# Altium schematic sheet mmb-2016-003_power.schdoc(mmb-2016-003_power)
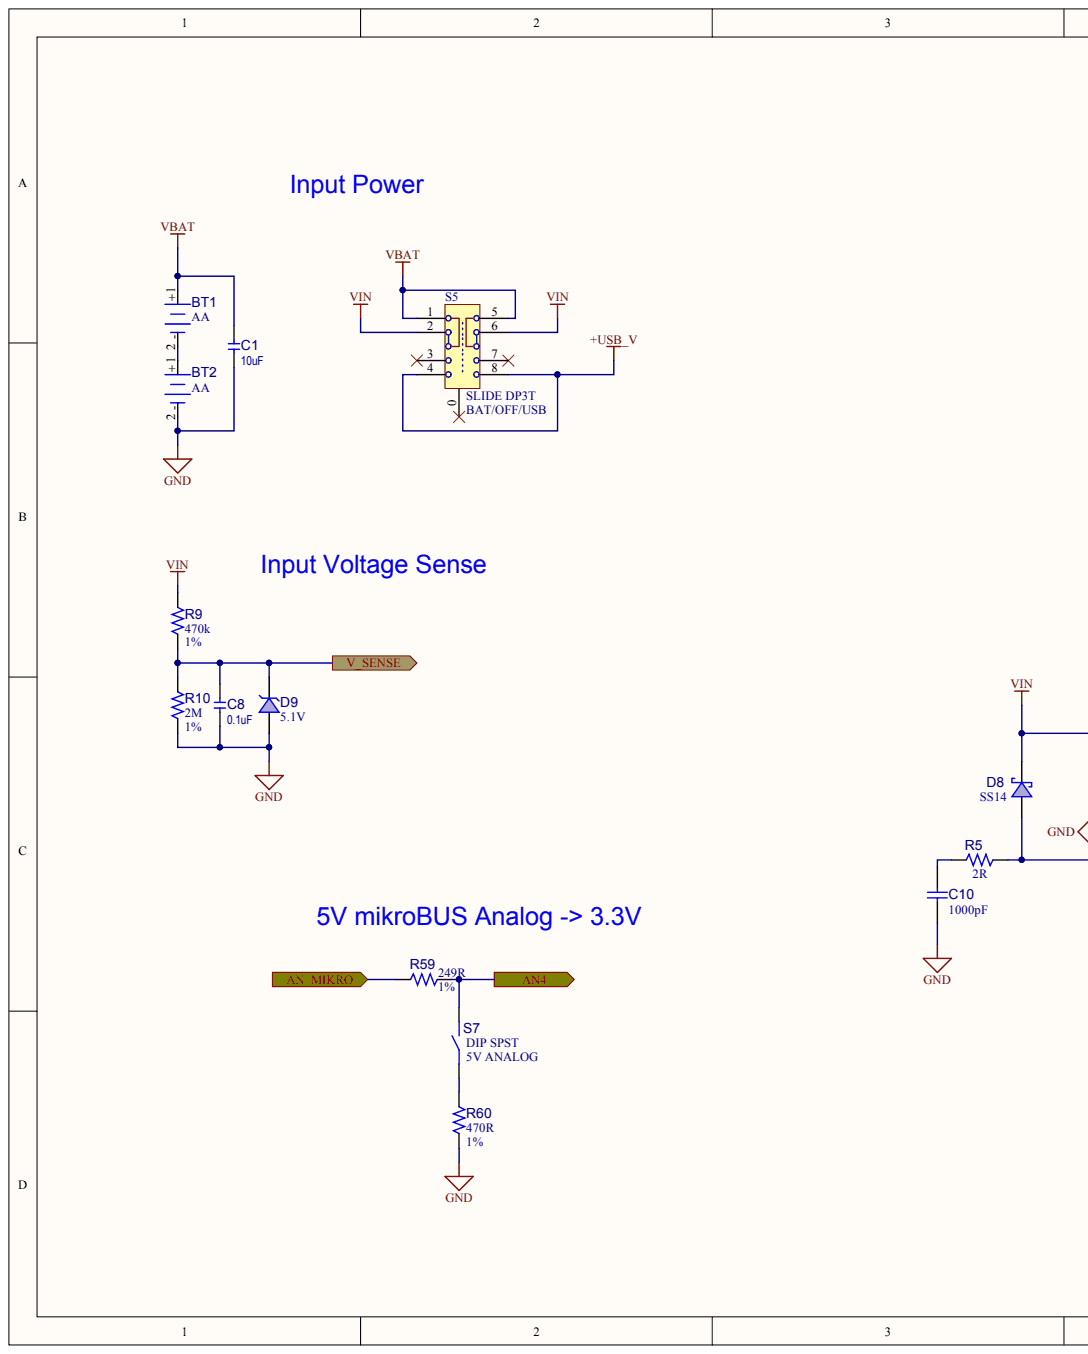
[diagram p0: mmb-2016-003_power.schdoc, left]
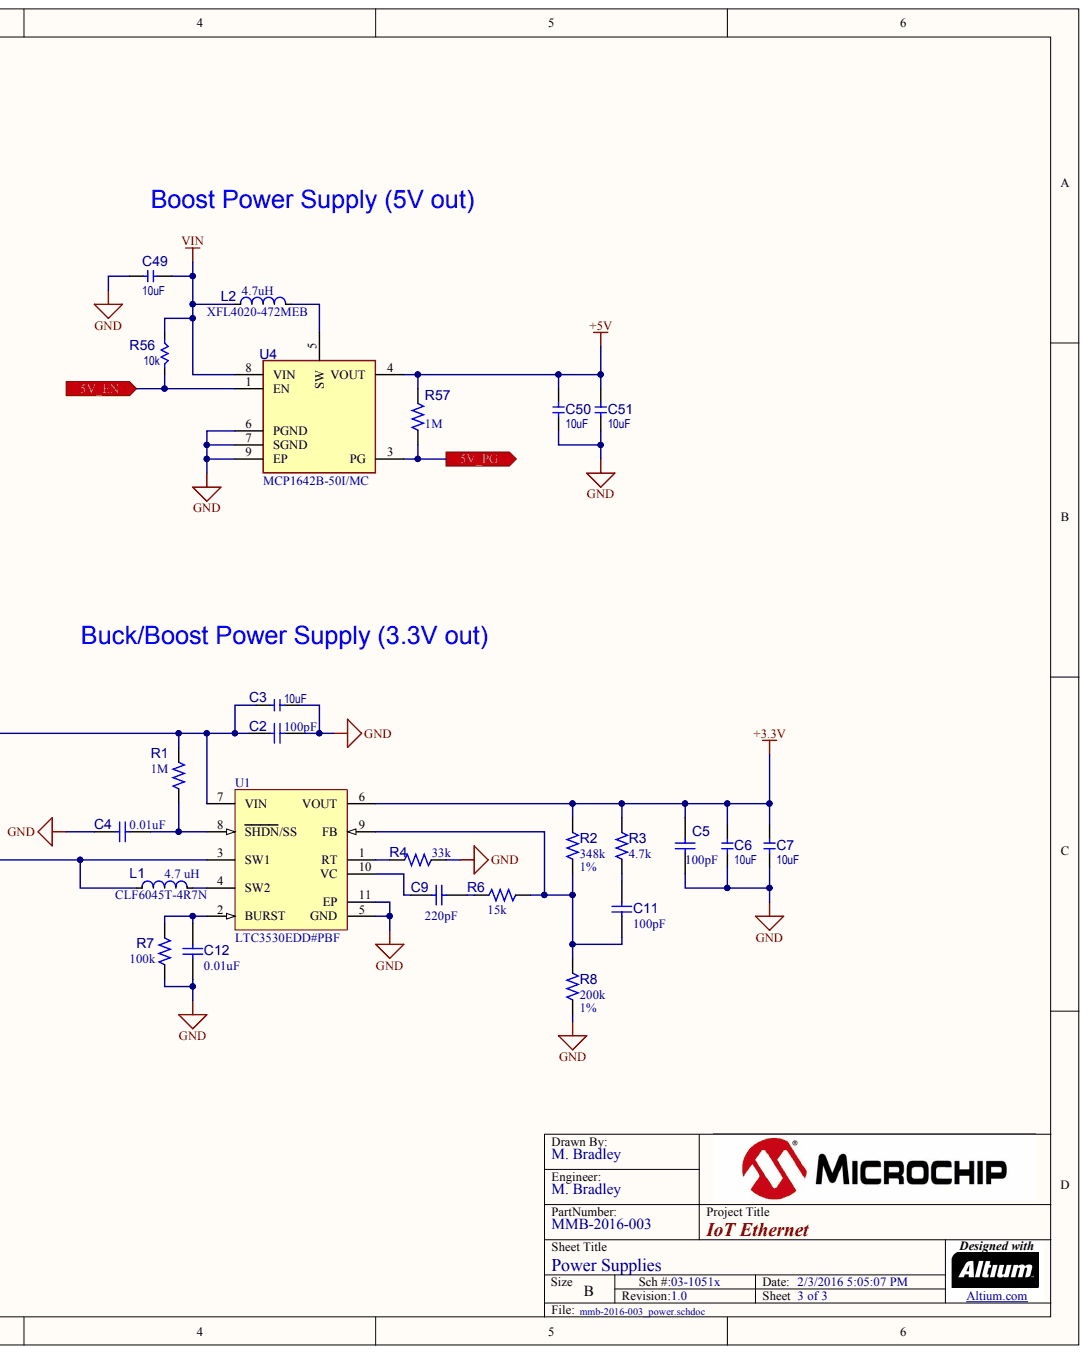
[diagram p1: mmb-2016-003_power.schdoc, right]

## Parts
BT1  value AA  [BAT_HOLDER_AA_2460]  (hidden comment: Battery 2 Pin)
BT2  value AA  [BAT_HOLDER_AA_2460]  (hidden comment: Battery 2 Pin)
C1  value 10uF  [CAP_C_0805]  (hidden comment: Capacitor NP)
C2  value 100pF  [CAP_C_0603]  (hidden comment: Capacitor NP)
C3  value 10uF  [CAP_C_0805]  (hidden comment: Capacitor NP)
C4  value 0.01uF  [CAP_C_0603]  (hidden comment: Capacitor NP)
C5  value 100pF  [CAP_C_0603]  (hidden comment: Capacitor NP)
C6  value 10uF  [CAP_C_0805]  (hidden comment: Capacitor NP)
C7  value 10uF  [CAP_C_0805]  (hidden comment: Capacitor NP)
C8  value 0.1uF  [CAP_C_0603]  (hidden comment: Capacitor NP)
C9  value 220pF  [CAP_C_0603]  (hidden comment: Capacitor NP)
C10  value 1000pF  [CAP_C_0805]  (hidden comment: Capacitor NP)
C11  value 100pF  [CAP_C_0603]  (hidden comment: Capacitor NP)
C12  value 0.01uF  [CAP_C_0603]  (hidden comment: Capacitor NP)
C49  value 10uF  [CAP_C_0805]  (hidden comment: Capacitor NP)
C50  value 10uF  [CAP_C_0805]  (hidden comment: Capacitor NP)
C51  value 10uF  [CAP_C_0805]  (hidden comment: Capacitor NP)
D8  value SS14  [DO-214AC_SMA]  (hidden comment: Diode Schottky)
D9  value 5.1V  [SOT-23-3]  (hidden comment: Diode Zener)
L1  CLF6045T-4R7N  value 4.7 uH  [IND_TDK_CLF6045]
L2  value 4.7uH  Manufacturer Part Number 1: XFL4020-472MEB  [IND_CFT_XFL4020_L4W4H2.1]  (hidden comment: Inductor)
R1  value 1M  [RES_C_0603]  (hidden comment: Resistor)
R2  value 348k  Tolerance: 1%  [RES_C_0603]  (hidden comment: Resistor)
R3  value 4.7k  [RES_C_0603]  (hidden comment: Resistor)
R4  value 33k  [RES_C_0603]  (hidden comment: Resistor)
R5  value 2R  [RES_C_0805]  (hidden comment: Resistor)
R6  value 15k  [RES_C_0603]  (hidden comment: Resistor)
R7  value 100k  [RES_C_0603]  (hidden comment: Resistor)
R8  value 200k  Tolerance: 1%  [RES_C_0603]  (hidden comment: Resistor)
R9  value 470k  Tolerance: 1%  [RES_C_0603]  (hidden comment: Resistor)
R10  value 2M  Tolerance: 1%  [RES_C_0603]  (hidden comment: Resistor)
R56  value 10k  [RES_C_0603]  (hidden comment: Resistor)
R57  value 1M  [RES_C_0603]  (hidden comment: Resistor)
R59  value 249R  Tolerance: 1%  [RES_C_0603]  (hidden comment: Resistor)
R60  value 470R  Tolerance: 1%  [RES_C_0603]  (hidden comment: Resistor)
S5  BAT/OFF/USB  value SLIDE DP3T  [SW_SLIDE_TH_OS203012MU5QP1]
S7  5V ANALOG  value DIP SPST  Type: DIP  [SW_SMD_2.54MM X 1 Wurth]
U1  LTC3530EDD#PBF  [LT-DD-10_V]
U4  MCP1642B-50I/MC  [DFN-8_2x3_VIAS]

## Ports
5V_EN, 5V_PG, AN4, AN_MIKRO, V_SENSE

## Nets
+3.3V: C5.2 C6.2 C7.2 R2.2 R3.2 U1.6(VOUT)
+5V: C50.1 C51.1 R57.2 U4.4(VOUT)
+USB_V: S5.4 S5.8
GND: BT2.2 C1.1 C2.1 C3.1 C4.2 C5.1 C6.1 C7.1 C8.1 C10.1 C12.1 C49.1 C50.2 C51.2 D9.1 R4.1 R7.1 R8.1 R10.1 R60.1 U1.5(GND) U1.11(EP) U4.6(PGND) U4.7(SGND) U4.9(EP)
VBAT: BT1.1 C1.2 S5.1 S5.5
VIN: C2.2 C3.2 C49.2 D8.2 L2.1 R1.2 R9.2 R56.2 S5.2 S5.6 U1.7(VIN) U4.8(VIN)
5V_EN: R56.1 U4.1(EN) ; port 5V_EN
5V_PG: R57.1 U4.3(PG) ; port 5V_PG
AN4: R59.2 S7.2 ; port AN4
AN_MIKRO: R59.1 ; port AN_MIKRO
V_SENSE: C8.2 D9.3 R9.1 R10.2 ; port V_SENSE
NetBT1_2: BT1.2 BT2.1
NetC4_1: C4.1 R1.1 U1.8(SHDN/SS)
NetC9_1: C9.1 U1.10(VC)
NetC9_2: C9.2 R6.1
NetC10_2: C10.2 R5.1
NetC11_1: C11.1 R2.1 R6.2 R8.2 U1.9(FB)
NetC11_2: C11.2 R3.1
NetC12_2: C12.2 R7.2 U1.2(BURST)
NetD8_1: D8.1 L1.1 R5.2 U1.3(SW1)
NetL1_2: L1.2 U1.4(SW2)
NetL2_2: L2.2 U4.5(SW)
NetR4_2: R4.2 U1.1(RT)
NetR60_2: R60.2 S7.1

Not connected: S5 0 3 7

## Library data of the parts
BT1 BT2 = BAT1011: Battery; Manufacturer 1: Keystone Electronics; Manufacturer Part Number 1: 2460; Description_: BATT HOLDER 2 TH AA; Owner: Tad Ahlvers; Populated: YES; Category: Battery; Component Kind: Standard; ComponentLink1Description: Datasheet; ComponentLink1URL: https://chn-vm-apps.microchip.com/mchprepo/parts/Datasheets/2460.pdf; Database Table Name: Battery; Library Name: MCHP-CDB-Offline.DbLib; MCHP-ID: BAT1011; Quantity Override: 1; Revision: A; Status: Design; Supplier 1: Digi-Key; Supplier Part Number 1: 2460K-ND; Type: Holder
C1 C3 C6 C7 C49-C51 = CAP0373: Capacitor; Manufacturer 1: Murata; Manufacturer Part Number 1: GRM21BR61C106KE15L; Description_: CAP CER 10uF 16V 10% X5R SMD 0805; Populated: Yes; Temperature Coeffcient: X5R; Tolerance: 10%; Type: Ceramic; Voltage: 16V; Category: Capacitor; Component Kind: Standard; ComponentLink1Description: Datasheet; ComponentLink1URL: https://chn-vm-apps.microchip.com/mchprepo/parts/Datasheets/L0005E.pdf; Database Table Name: Capacitor; Library Name: MCHP-CDB.DbLib; Manufacturer 2: Taiyo Yuden; Manufacturer Part Number 2: EMK212BJ106KG-T; MCHP-ID: CAP0373; Operating Temperature: +25C to +125C; Package: 0805; Quantity Override: 1; Revision: 1; Status: Production; Supplier 1: Digi-Key; Supplier 2: Digi-Key; Supplier 3: Newark; Supplier Part Number 1: 490-3886-1-ND; Supplier Part Number 2: 587-1295-1-ND; Supplier Part Number 3: 30K5459
C2 C5 C11 = CAP0035: Capacitor; Manufacturer 1: Panasonic; Manufacturer Part Number 1: ECU-V1H101JCV; Description_: CAP CER 100pF 50V 5% NP0 SMD 0603; Populated: Yes; Temperature Coeffcient: NP0; Tolerance: 5%; Type: Ceramic; Voltage: 50V; Category: Capacitor; Component Kind: Standard; ComponentLink1Description: Datasheet; ComponentLink1URL: https://chn-vm-apps.microchip.com/mchprepo/parts/Datasheets/ECJ__General_.pdf; Database Table Name: Capacitor; Library Name: MCHP-CDB-Offline.DbLib; Manufacturer 2: Cal-Chip; Manufacturer 3: NIC Components; Manufacturer 4: Panasonic; Manufacturer 5: AVX; Manufacturer 6: TDK; Manufacturer Part Number 2: GMC10CG101J50NTLF; Manufacturer Part Number 3: NMC0603NPO101J50TRPF; Manufacturer Part Number 4: ECJ-1VC1H101J; Manufacturer Part Number 5: 06035A101JAT2A; Manufacturer Part Number 6: C1608C0G1H101J/10; MCHP-ID: CAP0035; Operating Temperature: -55C to +125C; Package: 0603; Quantity Override: 1; Revision: 2; Status: Production; Supplier 1: Digi-Key; Supplier 2: Cal-Chip; Supplier 3: NIC Components; Supplier 4: Digi-Key; Supplier 5: Digi-Key; Supplier 6: Digi-Key; Supplier Part Number 1: PCC101ACVTR-ND; Supplier Part Number 2: GMC10CG101J50NTLF; Supplier Part Number 3: NMC0603NPO101J50TRPF; Supplier Part Number 4: PCC101ACVCT-ND; Supplier Part Number 5: 478-1175-1-ND; Supplier Part Number 6: C1608C0G1H101J/10-ND
C4 C12 = CAP0451: Capacitor; Manufacturer 1: Samsung; Manufacturer Part Number 1: CL10B103KO8NNNC; Description_: CAP CER 0.01uF 16V 10% X7R SMD 0603; Populated: Yes; Temperature Coeffcient: X7R; Tolerance: 10%; Type: Ceramic; Voltage: 16V; Category: Capacitor; Component Kind: Standard; ComponentLink1Description: Datasheet; ComponentLink1URL: https://chn-vm-apps.microchip.com/mchprepo/parts/Datasheets/L0005E.pdf; Database Table Name: Capacitor; Library Name: MCHP-CDB-Offline.DbLib; Manufacturer 2: Murata; Manufacturer 3: Panasonic; Manufacturer 4: Johanson Dielectrics; Manufacturer Part Number 2: GRM188R71C103KA01D; Manufacturer Part Number 3: ECJ-1VB1C103K; Manufacturer Part Number 4: 160R14W103KV4T; MCHP-ID: CAP0451; Operating Temperature: -55C to +125C; Package: 0603; Quantity Override: 1; Revision: 1; Status: Production; Supplier 1: Digi-Key; Supplier 2: Digi-Key; Supplier 3: Digi-Key; Supplier 4: Digi-Key; Supplier Part Number 1: 1276-1926-1-ND; Supplier Part Number 2: 490-1525-1-ND; Supplier Part Number 3: PCC1750CT-ND; Supplier Part Number 4: 709-1152-2-ND
C8 = CAP1166: Capacitor; Manufacturer 1: TDK; Manufacturer Part Number 1: C1608X7R1C104K; Description_: CAP CER 0.1uF 16V 10% X7R 0603; Owner: Bogdan P (M91398); Populated: Yes; Temperature Coeffcient: X7R; Tolerance: 10%; Type: Ceramic; Voltage: 16V; Category: Capacitor; Component Kind: Standard; ComponentLink1Description: Datasheet; ComponentLink1URL: https://chn-vm-apps.microchip.com/mchprepo/parts/Datasheets/general_B11.pdf; Database Table Name: Capacitor; Library Name: MCHP-CDB.DbLib; MCHP-ID: CAP1166; Operating Temperature: -55C to +125C; Package: 0603; Quantity Override: 1; Revision: 0; Status: Production; Supplier 1: Digi-Key; Supplier Part Number 1: 445-1317-1-ND
C9 = CAP0552: Capacitor; Manufacturer 1: Panasonic; Manufacturer Part Number 1: ECJ-1VC1H221J; Description_: CAP CER 220pF 50V 5% NP0 SMD 0603; Populated: Yes; Temperature Coeffcient: NP0; Tolerance: 5%; Type: Ceramic; Voltage: 50V; Category: Capacitor; Component Kind: Standard; ComponentLink1Description: Datasheet; ComponentLink1URL: https://chn-vm-apps.microchip.com/mchprepo/parts/Datasheets/KEM_C1002_X7R_SMD.pdf; Database Table Name: Capacitor; Library Name: MCHP-CDB-Offline.DbLib; Manufacturer 2: ROHM; Manufacturer 3: KEMET; Manufacturer 4: TDK; Manufacturer Part Number 2: MCH185A221JK; Manufacturer Part Number 3: C0603C221J5GACTU; Manufacturer Part Number 4: C1608C0G1H221J; MCHP-ID: CAP0552; Operating Temperature: -55C to +125C; Package: 0603; Quantity Override: 1; Revision: 2; Status: Production; Supplier 1: Digi-Key; Supplier 2: Digi-Key; Supplier 3: Digi-Key; Supplier 4: Digi-Key; Supplier Part Number 1: PCC221ACVCT-ND; Supplier Part Number 2: 511-1146-1-ND; Supplier Part Number 3: 399-1066-1-ND; Supplier Part Number 4: 445-1285-1-ND
C10 = CAP0505: Capacitor; Manufacturer 1: Yageo; Manufacturer Part Number 1: CC0805KRX7R9BB102; Description_: CAP CER 1000pF 50V 10% X7R SMD 0805; Populated: Yes; Temperature Coeffcient: X7R; Tolerance: 10%; Type: Ceramic; Voltage: 50V; Category: Capacitor; Component Kind: Standard; ComponentLink1Description: Datasheet; ComponentLink1URL: https://chn-vm-apps.microchip.com/mchprepo/parts/Datasheets/MLCC_ordering_code.pdf; Database Table Name: Capacitor; Library Name: MCHP-CDB-Offline.DbLib; MCHP-ID: CAP0505; Operating Temperature: -55C to +125C; Package: 0805; Quantity Override: 1; Revision: 1; Status: Production; Supplier 1: Digi-Key; Supplier Part Number 1: 311-1127-1-ND
D8 = DIODE0150: Manufacturer 1: Diodes Incorporated; Manufacturer Part Number 1: B140-13; Description_: DIO SCTKY SS14 500mV 1A 40V DO-214AC_SMA; Forward Current: 1A; Populated: Yes; Reverse Current: 200uA; Reverse Voltage: 40V; Type: Schottky Single; Category: Diode; Component Kind: Standard; ComponentLink1Description: Datasheet; ComponentLink1URL: https://chn-vm-apps.microchip.com/mchprepo/parts/Datasheets/ss12.pdf; Database Table Name: Diode; Library Name: MCHP-CDB-Offline.DbLib; Manufacturer 2: Diodes Incorporated; Manufacturer 3: Vishay; Manufacturer Part Number 2: B140-13-F; Manufacturer Part Number 3: SS14-E3/61T; MCHP-ID: DIODE0150; Operating Temperature: -65C to +125C; Package: DO-214AC_SMA; Quantity Override: 1; Revision: 2; Status: Production; Supplier 1: Digi-Key; Supplier 2: Diodes Incorporated; Supplier 3: Digi-Key; Supplier Part Number 1: B140DICT-ND; Supplier Part Number 2: B140-13-F; Supplier Part Number 3: SS14-E3/61TGICT-ND
D9 = DIODE0142: Manufacturer 1: Fairchild; Manufacturer Part Number 1: BZX84C5V1; Description_: DIO ZNR BZX84C5V1 5.1V 350mW SOT-23-3; Forward Current: 250mA; Populated: Yes; Power: 350mW; Type: Zener Single; Category: Diode; Component Kind: Standard; ComponentLink1Description: Datasheet; ComponentLink1URL: https://chn-vm-apps.microchip.com/mchprepo/parts/Datasheets/BZX84C10.pdf; Database Table Name: Diode; Library Name: MCHP-CDB-Offline.DbLib; MCHP-ID: DIODE0142; Operating Temperature: -55C to +150C; Package: SOT-23-3; Quantity Override: 1; Revision: 1; Status: Production; Supplier 1: Digi-Key; Supplier Part Number 1: BZX84C5V1FSCT-ND
L1 = IND_TDK_CLF6045: IND TDK CLF6045; Desciption_: Inductor TDK CLF6045T-4R7N 4.7uH; MCHP-ID: IND1182; Populated: Yes; Component Kind: Standard; Manufacturer 1: TDK; Manufacturer Part Number 1: CLF6045T-4R7N; Quantity Override: 1; Supplier 1: Digi-Key; Supplier Part Number 1: 445-15090-1-ND; Library Name: MMB-2014-002a.SchLib
L2 = IND1008: Manufacturer 1: Coilcraft; DCR: 0.0574R; Description_: INDUCTOR 4.7uH 2A 20% SMD XFL4020; Owner: Brent Lanphear; Populated: Yes; RMS Current: 5A; Saturation Current: 2A; Test Frequency: 100kHz; Category: Inductor; Component Kind: Standard; ComponentLink1Description: Datasheet; ComponentLink1URL: https://chn-vm-apps.microchip.com/mchprepo/parts/Datasheets/XFL4020.pdf; Database Table Name: Inductor; Description: Inductor; Library Name: MCHP-CDB-Offline.DbLib; MCHP-ID: IND1008; Operating Temperature: -40C to +125C; Package: SMD_XFL4020; Quantity Override: 1; Revision: 2; Status: Production; Supplier 1: Coilcraft; Supplier Part Number 1: XFL4020-472MEB; Type: Inductor
R1 R57 = RSMT0187: Manufacturer 1: Yageo; Manufacturer Part Number 1: 9C06031A1004JLHFT; Description_: RES TKF 1M 5% 1/10W SMD 0603; Populated: Yes; Power: 1/10W; Temperature Coeffcient: 100ppm/C; Tolerance: 5%; Voltage: 50V; Category: Resistor; Component Kind: Standard; ComponentLink1Description: Datasheet; ComponentLink1URL: https://chn-vm-apps.microchip.com/mchprepo/parts/Datasheets/PYu-RC0603_51_RoHS_L_4.pdf; Composition: TKF; Database Table Name: Resistor; Description: Resistor; Library Name: MCHP-CDB-Offline.DbLib; Manufacturer 2: KOA Speer; Manufacturer 3: Panasonic; Manufacturer 4: Stackpole Electronics Inc; Manufacturer 5: Yageo; Manufacturer 6: Yageo; Manufacturer 7: Stackpole Electronics Inc; Manufacturer Part Number 2: RK73B1JTTD105J; Manufacturer Part Number 3: ERJ-3GEYJ105V; Manufacturer Part Number 4: RMCF1/161M5%R; Manufacturer Part Number 5: RC0603JR-071ML; Manufacturer Part Number 6: 9C06031A1004JLPFT; Manufacturer Part Number 7: RMCF0603JT1M00; MCHP-ID: RSMT0187; Operating Temperature: -55C to +155C; Package: 0603; Quantity Override: 1; Revision: 1; Status: Production; Supplier 1: Yageo; Supplier 2: KOA Speer; Supplier 3: Digi-Key; Supplier 4: Stackpole Electronics Inc; Supplier 5: Yageo; Supplier 6: Yageo; Supplier 7: Digi-Key; Supplier Part Number 1: 9C06031A1004JLHFT; Supplier Part Number 2: RK73B1JTTD105J; Supplier Part Number 3: P1.0MGTR-ND; Supplier Part Number 4: RMCF1/161M5%R; Supplier Part Number 5: RC0603JR-071ML; Supplier Part Number 6: 9C06031A1004JLPFT; Supplier Part Number 7: RMCF0603JT1M00CT-ND; Type: RES SMD
R2 = RSMT1057: Manufacturer 1: Stackpole Electronics Inc; Manufacturer Part Number 1: RMCF0603FT348K; Current: 1A; Description_: RES TKF 348k 1/10W 1% SMD 0603; Owner: Leah Whitaker; Populated: YES; Power: 1/10W; Temperature Coeffcient: 100ppm/C; Voltage: 50V; Category: Resistor; Component Kind: Standard; ComponentLink1Description: Datasheet; ComponentLink1URL: https://chn-vm-apps.microchip.com/mchprepo/parts/Datasheets/SEI-RMCF_RMCP.pdf; Composition: TKF; Database Table Name: Resistor; Description: Resistor; Library Name: MCHP-CDB-Offline.DbLib; MCHP-ID: RSMT1057; Operating Temperature: -55C to +155C; Package: 0603; Quantity Override: 1; Revision: 0; Status: Production; Supplier 1: Digi-Key; Supplier Part Number 1: RMCF0603FT348KCT-ND; Type: RES SMD
R3 = RSMT0092: Manufacturer 1: Yageo; Manufacturer Part Number 1: RC0603JR-074K7L; Description_: RES TKF 4.7k 5% 1/10W SMD 0603; Populated: Yes; Power: 1/10W; Temperature Coeffcient: 100ppm/C; Tolerance: 5%; Voltage: 50V; Category: Resistor; Component Kind: Standard; ComponentLink1Description: Datasheet; ComponentLink1URL: https://chn-vm-apps.microchip.com/mchprepo/parts/Datasheets/PYu-RC0603_51_RoHS_L_4.pdf; Composition: TKF; Database Table Name: Resistor; Description: Resistor; Library Name: MCHP-CDB-Offline.DbLib; Manufacturer 2: Yageo; Manufacturer 3: KOA Speer; Manufacturer 4: Panasonic; Manufacturer 5: ROHM; Manufacturer 6: Stackpole Electronics Inc; Manufacturer 7: Yageo; Manufacturer 8: NIC Components; Manufacturer Part Number 2: 9C06031A4701JLHFT; Manufacturer Part Number 3: RK73B1JTTD472J; Manufacturer Part Number 4: ERJ-3GEYJ472V; Manufacturer Part Number 5: MCR03EZHJ4.7K; Manufacturer Part Number 6: RMCF 1/16 4.7K 5% R; Manufacturer Part Number 7: 9C06031A4701JLPFT; Manufacturer Part Number 8: NRC06J472TRF; MCHP-ID: RSMT0092; Operating Temperature: -55C to +155C; Package: 0603; Quantity Override: 1; Revision: 1; Status: Production; Supplier 1: Digi-Key; Supplier 2: Digi-Key; Supplier 3: KOA Speer; Supplier 4: Digi-Key; Supplier 5: Digi-Key; Supplier 6: ROHM; Supplier 7: Stackpole Electronics Inc; Supplier 8: Yageo; Supplier 9: Avnet; Supplier Part Number 1: 311-4.7KGRTR-ND; Supplier Part Number 2: 311-4.7KGTR-ND; Supplier Part Number 3: RK73B1JTTD472J; Supplier Part Number 4: P4.7KGTR-ND; Supplier Part Number 5: P4.7KGCT-ND; Supplier Part Number 6: MCR03EZHJ4.7K; Supplier Part Number 7: RMCF 1/16 4.7K 5% R; Supplier Part Number 8: 9C06031A4701JLPFT; Supplier Part Number 9: NRC06J472TRF; Type: RES SMD
R4 = RSMT0499: Manufacturer 1: Panasonic; Manufacturer Part Number 1: ERJ-3GEYJ333V; Description_: RES TKF 33k 5% 1/10W SMD 0603; Populated: Yes; Power: 1/10W; Temperature Coeffcient: 200ppm/C; Tolerance: 5%; Voltage: 75V; Category: Resistor; Component Kind: Standard; ComponentLink1Description: Datasheet; ComponentLink1URL: https://chn-vm-apps.microchip.com/mchprepo/parts/Datasheets/AOA0000CE1.pdf; Composition: TKF; Database Table Name: Resistor; Description: Resistor; Library Name: MCHP-CDB-Offline.DbLib; MCHP-ID: RSMT0499; Operating Temperature: -55C to +155C; Package: 0603; Quantity Override: 1; Revision: 1; Status: Production; Supplier 1: Digi-Key; Supplier 2: Digi-Key; Supplier Part Number 1: P33KGTR-ND; Supplier Part Number 2: P33KGCT-ND; Type: RES SMD
R5 = RSMT0359: Manufacturer 1: Yageo; Manufacturer Part Number 1: 9C08052A2R00FGHFT; Description_: RES TKF 2R 1% 1/8W SMD 0805; Populated: Yes; Power: 1/8W; Temperature Coeffcient: 100ppm/C; Tolerance: 1%; Voltage: 150V; Category: Resistor; Component Kind: Standard; ComponentLink1Description: Datasheet; ComponentLink1URL: https://chn-vm-apps.microchip.com/mchprepo/parts/Datasheets/PYu-RC0805_51_RoHS_L_4.pdf; Composition: TKF; Database Table Name: Resistor; Description: Resistor; Library Name: MCHP-CDB-Offline.DbLib; Manufacturer 2: Yageo; Manufacturer Part Number 2: 9C08052A2R00FGPFT; MCHP-ID: RSMT0359; Operating Temperature: -55C to +155C; Package: 0805; Quantity Override: 1; Revision: 1; Status: Production; Supplier 1: Digi-Key; Supplier 2: Yageo; Supplier Part Number 1: 311-2.00CTR-ND; Supplier Part Number 2: 9C08052A2R00FGPFT; Type: RES SMD
R6 = RSMT0498: Manufacturer 1: Panasonic; Manufacturer Part Number 1: ERJ-3GEYJ153V; Description_: RES TKF 15k 5% 1/10W SMD 0603; Populated: Yes; Power: 1/10W; Temperature Coeffcient: 200ppm/C; Tolerance: 5%; Voltage: 75V; Category: Resistor; Component Kind: Standard; ComponentLink1Description: Datasheet; ComponentLink1URL: https://chn-vm-apps.microchip.com/mchprepo/parts/Datasheets/AOA0000CE1.pdf; Composition: TKF; Database Table Name: Resistor; Description: Resistor; Library Name: MCHP-CDB-Offline.DbLib; MCHP-ID: RSMT0498; Operating Temperature: -55C to +155C; Package: 0603; Quantity Override: 1; Revision: 1; Status: Production; Supplier 1: Digi-Key; Supplier 2: Digi-Key; Supplier Part Number 1: P15KGTR-ND; Supplier Part Number 2: P15KGCT-ND; Type: RES SMD
R7 = RSMT0026: Manufacturer 1: Panasonic; Manufacturer Part Number 1: ERJ-3GEYJ104V; Description_: RES TKF 100k 5% 1/10W SMD 0603; Populated: Yes; Power: 1/10W; Temperature Coeffcient: 200ppm/C; Tolerance: 5%; Voltage: 75V; Category: Resistor; Component Kind: Standard; ComponentLink1Description: Datasheet; ComponentLink1URL: https://chn-vm-apps.microchip.com/mchprepo/parts/Datasheets/AOA0000CE1.pdf; Composition: TKF; Database Table Name: Resistor; Description: Resistor; Library Name: MCHP-CDB-Offline.DbLib; Manufacturer 2: KOA Speer; Manufacturer 3: Panasonic; Manufacturer 4: ROHM; Manufacturer 5: Stackpole Electronics Inc; Manufacturer Part Number 2: RK73B1JTTD104J; Manufacturer Part Number 3: ERJ-3GSYJ104V; Manufacturer Part Number 4: MCR03EZPJ104; Manufacturer Part Number 5: RMCF 1/16 100K 5% R; MCHP-ID: RSMT0026; Operating Temperature: -55C to +155C; Package: 0603; Quantity Override: 1; Revision: 2; Status: Production; Supplier 1: Digi-Key; Supplier 2: KOA Speer; Supplier 3: Digi-Key; Supplier 4: Stackpole Electronics Inc; Supplier Part Number 1: P100KGTR-ND; Supplier Part Number 2: RK73B1JTTD104J; Supplier Part Number 3: RHM100KGTR-ND; Supplier Part Number 4: RMCF 1/16 100K 5% R; Type: RES SMD
R8 = RES1140: Manufacturer 1: Vishay; Manufacturer Part Number 1: CRCW0603200KFKEA; Description_: RES TKF 200k 1% 1/10W SMD 0603; Owner: Mihai T(M91442); Populated: Yes; Power: 1/10W; Temperature Coeffcient: 100ppm/C; Voltage: 75V; Category: Resistor; Component Kind: Standard; ComponentLink1Description: Datasheet; ComponentLink1URL: https://chn-vm-apps.microchip.com/mchprepo/parts/Datasheets/dcrcwe3.pdf; Composition: TKF; Database Table Name: Resistor; Description: Resistor; Library Name: MCHP-CDB-Offline.DbLib; MCHP-ID: RES1140; Operating Temperature: -55C to +155C; Package: 0603; Quantity Override: 1; Revision: 0; Status: Production; Supplier 1: Digi-Key; Supplier 2: Farnell; Supplier Part Number 1: 541-200KHCT-ND; Supplier Part Number 2: 1469776; Type: RES SMD
R9 = RSMT1056: Manufacturer 1: Panasonic; Manufacturer Part Number 1: ERJ-3EKF4703V; Description_: RES TKF 470k 1% 1/10W SMD 0603; Owner: Leah Whitaker; Populated: YES; Power: 1/10W; Temperature Coeffcient: 100ppm/C; Voltage: 75V; Category: Resistor; Component Kind: Standard; ComponentLink1Description: Datasheet; ComponentLink1URL: https://chn-vm-apps.microchip.com/mchprepo/parts/Datasheets/AOA0000CE2.pdf; Composition: TKF; Database Table Name: Resistor; Description: Resistor; Library Name: MCHP-CDB-Offline.DbLib; MCHP-ID: RSMT1056; Operating Temperature: -55C to +155C; Package: 0603; Quantity Override: 1; Revision: 1; Status: Production; Supplier 1: Digi-Key; Supplier Part Number 1: P470KHCT-ND; Type: RES SMD
R10 = RSMT0785: Manufacturer 1: Yageo; Manufacturer Part Number 1: RC0603FR-072ML; Description_: RES TKF 2M 1% 1/10W SMD 0603; Populated: Yes; Power: 1/10W; Temperature Coeffcient: 100ppm/C; Voltage: 50V; Category: Resistor; Component Kind: Standard; ComponentLink1Description: Datasheet; ComponentLink1URL: https://chn-vm-apps.microchip.com/mchprepo/parts/Datasheets/PYu-RC0603_51_RoHS_L_4.pdf; Composition: TKF; Database Table Name: Resistor; Description: Resistor; Library Name: MCHP-CDB-Offline.DbLib; MCHP-ID: RSMT0785; Operating Temperature: -55C to +155C; Package: 0603; Quantity Override: 1; Revision: 1; Status: Production; Supplier 1: Digi-Key; Supplier Part Number 1: 311-2.00MHRTR-ND; Type: RES SMD
R56 = RES0191: Manufacturer 1: Panasonic; Manufacturer Part Number 1: ERJ-3GEYJ103V; Description_: RES TKF 10k 5% 1/10W SMD 0603; Populated: Yes; Power: 1/10W; Temperature Coeffcient: 200ppm/C; Tolerance: 5%; Voltage: 75V; Category: Resistor; Component Kind: Standard; ComponentLink1Description: Datasheet; ComponentLink1URL: https://chn-vm-apps.microchip.com/mchprepo/parts/Datasheets/AOA0000CE1.pdf; Composition: TKF; Database Table Name: Resistor; Description: Resistor; Library Name: MCHP-CDB.DbLib; Manufacturer 2: NIC Components; Manufacturer 3: Yageo; Manufacturer Part Number 2: NRC06J103TRF; Manufacturer Part Number 3: RC0603JR-0710KL; MCHP-ID: RES0191; Operating Temperature: -55C to +155C; Package: 0603; Quantity Override: 1; Revision: 2; Status: Production; Supplier 1: Digi-Key; Supplier 2: Digi-Key; Supplier 3: NIC Components; Supplier 4: Digi-Key; Supplier Part Number 1: P10KGCT-ND; Supplier Part Number 2: P10KGTR-ND; Supplier Part Number 3: NRC06J103TRF; Supplier Part Number 4: 311-10KGRTR-ND; Type: RES SMD
R59 = RSMT0672: Manufacturer 1: Panasonic; Manufacturer Part Number 1: ERJ-3EKF2490V; Description_: RES TKF 249R 1% 1/10W SMD 0603; Populated: Yes; Power: 1/10W; Temperature Coeffcient: 100ppm/C; Voltage: 50V; Category: Resistor; Component Kind: Standard; ComponentLink1Description: Datasheet; ComponentLink1URL: https://chn-vm-apps.microchip.com/mchprepo/parts/Datasheets/PYu-RC0603_51_RoHS_L_4.pdf; Composition: TKF; Database Table Name: Resistor; Description: Resistor; Library Name: MCHP-CDB-Offline.DbLib; Manufacturer 2: Yageo; Manufacturer Part Number 2: RC0603FR-07249RL; MCHP-ID: RSMT0672; Operating Temperature: -55C to +155C; Package: 0603; Quantity Override: 1; Revision: 2; Status: Production; Supplier 1: Digi-Key; Supplier 2: Digi-Key; Supplier Part Number 1: P249HCT-ND; Supplier Part Number 2: 311-249HRCT-ND; Type: RES SMD
R60 = RSMT0518: Manufacturer 1: Yageo; Manufacturer Part Number 1: RC0603FR-07470RL; Description_: RES TKF 470R 1% 1/10W SMD 0603; Populated: Yes; Power: 1/10W; Temperature Coeffcient: 100ppm/C; Voltage: 50V; Category: Resistor; Component Kind: Standard; ComponentLink1Description: Datasheet; ComponentLink1URL: https://chn-vm-apps.microchip.com/mchprepo/parts/Datasheets/PYu-RC0603_51_RoHS_L_4.pdf; Composition: TKF; Database Table Name: Resistor; Description: Resistor; Library Name: MCHP-CDB-Offline.DbLib; Manufacturer 2: Panasonic; Manufacturer 3: Stackpole Electronics Inc; Manufacturer Part Number 2: ERJ-3EKF4700V; Manufacturer Part Number 3: RMCF0603FT470R; MCHP-ID: RSMT0518; Operating Temperature: -55C to +155C; Package: 0603; Quantity Override: 1; Revision: 1; Status: Production; Supplier 1: Digi-Key; Supplier 2: Digi-Key; Supplier 3: Digi-Key; Supplier Part Number 1: 311-470HRCT-ND; Supplier Part Number 2: P470HCT-ND; Supplier Part Number 3: RMCF1/16470FRTR-ND; Type: RES SMD
S5 = SWITCH1025: Double-Pole, 3-Throw Switch With Commons; Manufacturer 1: C&K Components; Manufacturer Part Number 1: OS203012MU5QP1; Current: 100mA; Description_: SWITCH SLIDE DP3T 30V 100mA OS203012MU5QP1 TH; Populated: YES; Voltage: 30V; Category: Switch; Component Kind: Standard; ComponentLink1Description: Datasheet; ComponentLink1URL: https://chn-vm-apps.microchip.com/mchprepo/parts/Datasheets/OS_11mar13-1.pdf; Database Table Name: Switch; Library Name: MCHP-CDB.DbLib; MCHP-ID: SWITCH1025; Quantity Override: 1; Revision: A; Status: Design; Supplier 1: Digi-Key; Supplier Part Number 1: CKN9554-ND; Type: Slide
S7 = SWITCH1101: Switch; Manufacturer 1: Wurth Electronics Inc; Manufacturer Part Number 1: 418121270801; Current: 25mA; Description_: SWITCH DIP SPST 24V 25mA 418121270801 SMD; Owner: Mihai T(M91442); Populated: Yes; Voltage: 24V; Category: Switch; Component Kind: Standard; ComponentLink1Description: Datasheet; ComponentLink1URL: https://chn-vm-apps.microchip.com/mchprepo/parts/Datasheets/4181212708xx.pdf; Database Table Name: Switch; Library Name: MCHP-CDB-Offline.DbLib; MCHP-ID: SWITCH1101; Operating Temperature: -40C to +85C; Quantity Override: 1; Revision: 0; Status: Production; Supplier 1: Wurth Electronics Inc; Supplier Part Number 1: 418121270801
U1 = LTC3530EDD#PBF: Wide Input Voltage Synchronous Buck-Boost DC/DC Converter, 10-pin SON (DD-10), -40 to 85 degC, Pb-Free; Manufacturer: Linear Technology; Frequency Adjust Range: 300kHz - 2MHz; Number of Outputs: 1; Code_JEDEC: MO-229; Component Kind: Standard; ComponentLink1Description: Manufacturer URL; ComponentLink1URL: http://www.linear.com/; ComponentLink2Description: Datasheet; ComponentLink2URL: http://cds.linear.com/docs/en/datasheet/3530fb.pdf; ComponentLink3Description: Package Specification; ComponentLink3URL: http://cds.linear.com/docs/en/packaging/DFN_10_05-08-1699.pdf; DatasheetVersion: Rev. B; Library Name: Linear Technology Power Management Switching Regulator LTC1174-LTC3901.IntLib; Mounting Technology: Surface Mount; PackageDescription: 10-Pin Plastic DFN, Body 3 x 3 mm, Pitch 0.5 mm; PackageReference: DD-10; PackageVersion: Rev. C, 06/2010; PartNumber: LTC3530EDD#PBF; RoHS: TRUE; Supplier 1: Digi-Key; Supplier 2: Newark; Supplier Part Number 1: LTC3530EDD#PBF-ND; Supplier Part Number 2: 56M7925
U4 = MCP1642 DFN: DC-DC Convertor; Manufacturer 1: Microchip Technology Inc; Description_: MCHP ANALOG SWITCHER BOOST 5.0V MCP1642B-50I/MC DFN-8; MCHP-ID: MIC6251; Populated: Yes; Component Kind: Standard; Manufacturer Part Number 1: MCP1642B-50I/MC; Quantity Override: 1; Supplier 1: Microchip; Supplier Part Number 1: MCP1642B-50I/MC; Library Name: MMB-2014-003a.SchLib
